# Altium schematic sheet CW551_MainBoard_Ethernet.SchDoc
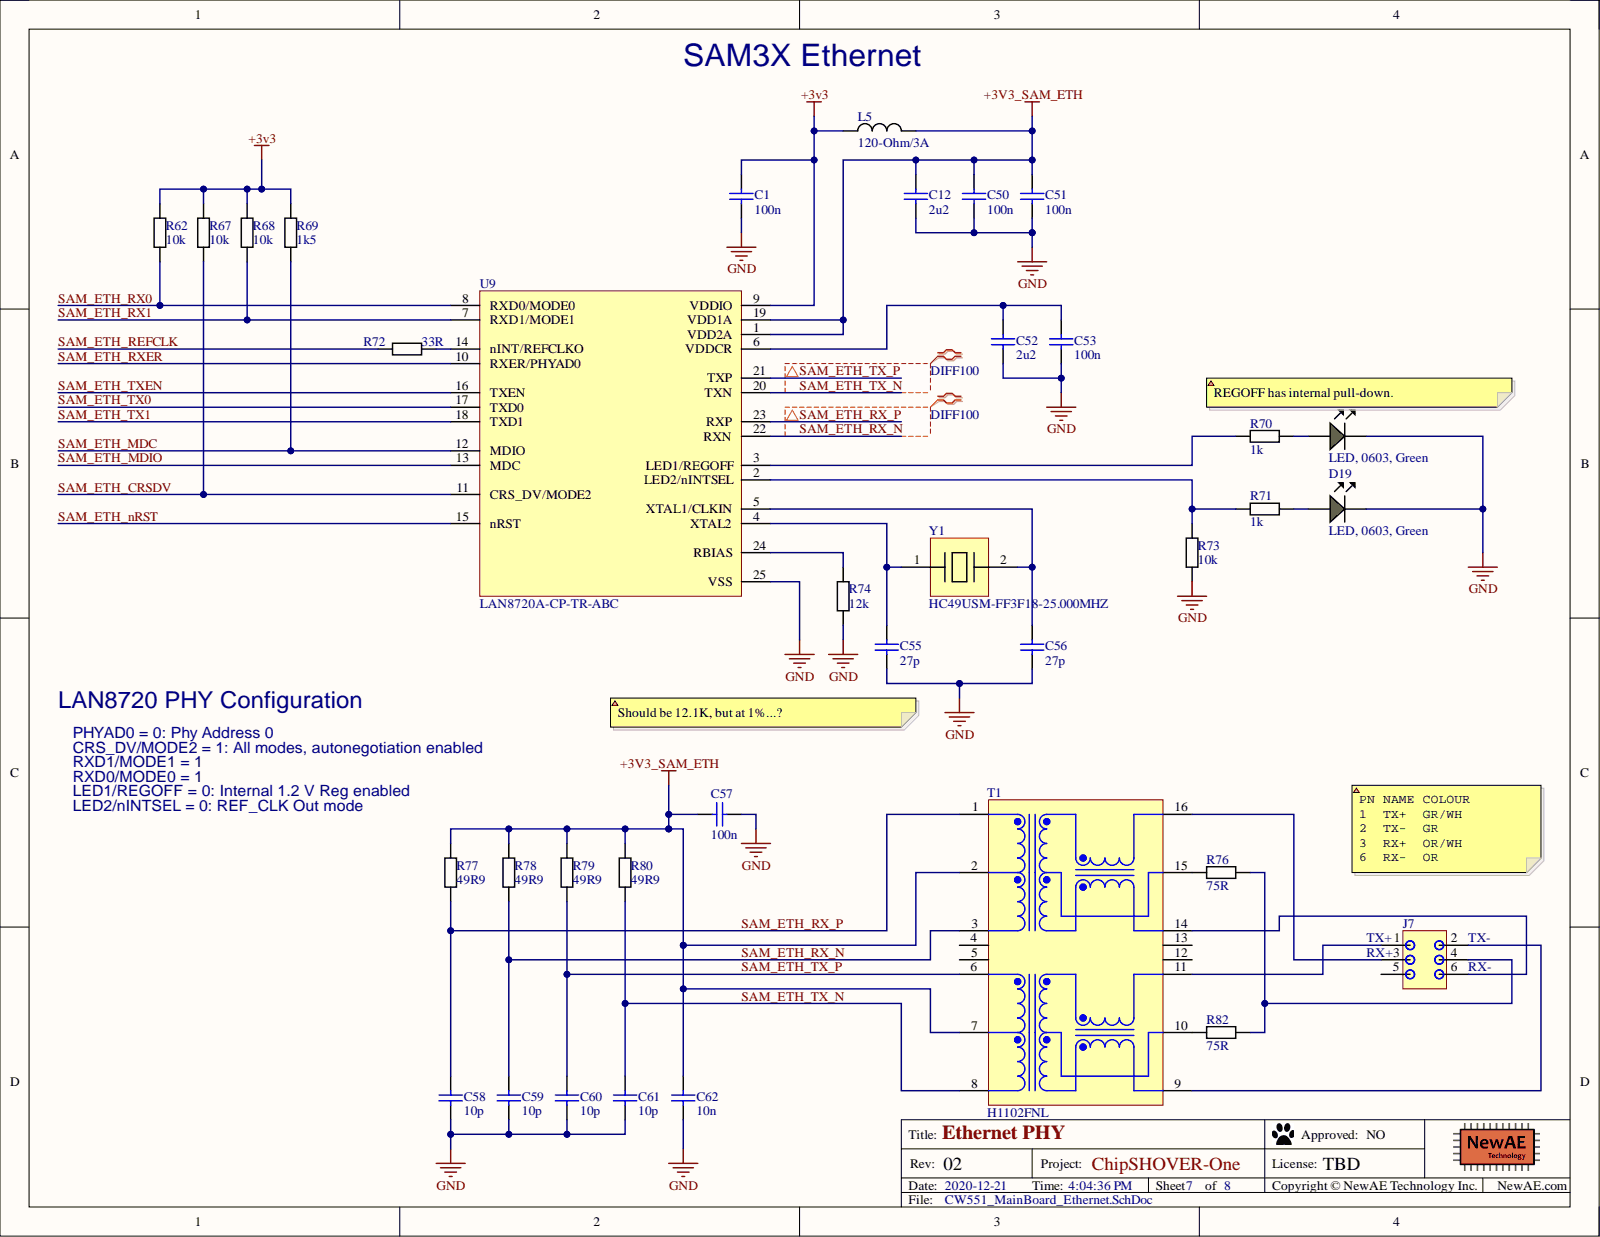
[diagram p0: CW551_MainBoard_Ethernet.SchDoc]

## Parts
C1  100n  [0603]
C12  2u2  [0603]
C50  100n  [0603]
C51  100n  [0603]
C52  2u2  [0603]
C53  100n  [0603]
C55  27p  [0603]
C56  27p  [0603]
C57  100n  [0402]
C58  10p  [0603]
C59  10p  [0603]
C60  10p  [0603]
C61  10p  [0603]
C62  10n  [0603]
D18  LED, 0603, Green  [LED-0603-GREEN]
D19  LED, 0603, Green  [LED-0603-GREEN]
J7  HDR, Male, 6-Pin, 2 Row, Shrouded  [HDR_6PIN_2ROW_SHROUD]  (value not drawn)
L5  120-Ohm/3A  [0603]
R62  10k  [0603]
R67  10k  [0603]
R68  10k  [0603]
R69  1k5  [0603]
R70  1k  [0603]
R71  1k  [0603]
R72  33R  [0603]
R73  10k  [0603]
R74  12k  [0603]
R76  75R  [0603]
R77  49R9  [0805]
R78  49R9  [0805]
R79  49R9  [0805]
R80  49R9  [0805]
R82  75R  [0603]
T1  H1102FNL  [PULS-H1102FNL_V]
U9  LAN8720A-CP-TR-ABC  [QFN50P400X400X90_HS-25N]
Y1  HC49USM-FF3F18-25.000MHZ  [HC49SD]

## Nets
+3v3: C1.1 C12.1 C50.1 C51.1 C57.1 C62.1 L5.1 L5.2 R62.1 R67.1 R68.1 R69.1 R77.1 R78.1 R79.1 R80.1 T1.2 T1.7 U9.1(VDD2A) U9.9(VDDIO) U9.19(VDD1A)
GND: C1.2 C12.2 C50.2 C51.2 C52.2 C53.2 C55.2 C56.2 C57.2 C58.2 C59.2 C60.2 C61.2 C62.2 D18.K D19.K R73.2 R74.2 U9.25(VSS)
SAM_ETH_CRSDV: R67.2 U9.11(CRS_DV/MODE2)
SAM_ETH_MDC: R69.2 U9.12(MDIO)
SAM_ETH_MDIO: U9.13(MDC)
SAM_ETH_nRST: U9.15(nRST)
SAM_ETH_REFCLK: R72.1
SAM_ETH_RX0: R62.2 U9.8(RXD0/MODE0)
SAM_ETH_RX1: R68.2 U9.7(RXD1/MODE1)
SAM_ETH_RX_N: C59.1 R78.2 T1.3 U9.22(RXN)
SAM_ETH_RX_P: C58.1 R77.2 T1.1 U9.23(RXP)
SAM_ETH_RXER: U9.10(RXER/PHYAD0)
SAM_ETH_TX0: U9.17(TXD0)
SAM_ETH_TX1: U9.18(TXD1)
SAM_ETH_TX_N: C61.1 R80.2 T1.8 U9.20(TXN)
SAM_ETH_TX_P: C60.1 R79.2 T1.6 U9.21(TXP)
SAM_ETH_TXEN: U9.16(TXEN)
NetC52_1: C52.1 C53.1 U9.6(VDDCR)
NetC55_1: C55.1 U9.4(XTAL2) Y1.1
NetC56_1: C56.1 U9.5(XTAL1/CLKIN) Y1.2
NetD18_A: D18.A R70.2
NetD19_A: D19.A R71.2
NetJ7_1: J7.1 T1.11
NetJ7_2: J7.2 T1.9
NetJ7_3: J7.3 T1.16
NetJ7_4: J7.4 R76.2 R82.2
NetJ7_6: J7.6 T1.14
NetR70_1: R70.1 U9.3(LED1/REGOFF)
NetR71_1: R71.1 R73.1 U9.2(LED2/nINTSEL)
NetR72_2: R72.2 U9.14(nINT/REFCLKO)
NetR74_1: R74.1 U9.24(RBIAS)
NetR76_1: R76.1 T1.15
NetR82_1: R82.1 T1.10

Not connected: J7 5; T1 4 5 12 13

## Library data of the parts
C1 C50 C51 C53 = CCHIP-100n-0603: 100n, 0603; Manufacture 1: Kemet; Manufacture Part Number 1: C0603C104K5RACTU; Case-Metric: 1608; Component Group: Capacitor; Database Table Name: main; Rated Voltage: 50VDC; Case-EIA: 0603; Component Kind: Standard; Component Type: Standard; LCSC Equivalent: C1591; Library Name: NewAE_AltiumLib.DBLib; Mounting Technology: SMT; Part Number: CCHIP-100n-0603; Pin Count: 2; Supplier 1: Digi-Key; Supplier Part Number 1: 399-5089-2-ND; Value: 100n
C12 C52 = CCHIP-2u2-0603: 2u2, 0603; Manufacture 1: Samsung; Manufacture Part Number 1: CL10B225KP8NNNC; Case-Metric: 1608; Component Group: Capacitor; Database Table Name: main; Rated Voltage: 10VDC; Case-EIA: 0603; Component Kind: Standard; Component Type: Standard; LCSC Equivalent: C57895; Library Name: NewAE_AltiumLib.DBLib; Mounting Technology: SMT; Part Number: CCHIP-2u2-0603; Pin Count: 2; Supplier 1: Digi-Key; Supplier Part Number 1: 1276-1134-2-ND; Value: 2u2
C55 C56 = CCHIP-27P-0603-50V: CAP CER 27PF 50V C0G/NP0 0603; Manufacture 1: Murata Electronics North America; Manufacture Part Number 1: GRM1885C1H270JA01D; Case-Metric: 1608; Component Group: Capacitor; Database Table Name: main; PP Material Stack: 0; Tolerance: ±5%; Case-EIA: 0603; Component Kind: Standard; Component Type: Standard; LCSC Equivalent: C85976; Library Name: NewAE_AltiumLib.DBLib; Mounting Technology: SMT; Part Number: CCHIP-27P-0603-50V; Pin Count: 2; PP Rotation: 0; Rated Voltage: 50V; Supplier 1: Digi-Key; Supplier Part Number 1: 490-1413-1-ND; Value: 27p
C57 = CCHIP-100n-0402: 100n, 0402; Component Group: Capacitor; Database Table Name: main; Case-EIA: 0402; Component Kind: Standard; Component Type: Standard; Library Name: NewAE_AltiumLib.DBLib; Mounting Technology: SMT; Part Number: CCHIP-100n-0402; Pin Count: 2; Value: 100n
C58-C61 = CCHIP-10p-0603: 10p, 0603; Case-Metric: 1608; Component Group: Capacitor; Database Table Name: main; Case-EIA: 0603; Component Kind: Standard; Component Type: Standard; LCSC Equivalent: C313084; Library Name: NewAE_AltiumLib.DBLib; Mounting Technology: SMT; Part Number: CCHIP-10p-0603; Pin Count: 2; Supplier 1: Digi-Key; Supplier Part Number 1: 399-1049-1-ND; Value: 10p
C62 = CCHIP-10n-0603: 10n, 0603; Case-Metric: 1608; Component Group: Capacitor; Database Table Name: main; Case-EIA: 0603; Component Kind: Standard; Component Type: Standard; Library Name: NewAE_AltiumLib.DBLib; Mounting Technology: SMT; Part Number: CCHIP-10n-0603; Pin Count: 2; Supplier 1: Digi-Key; Supplier Part Number 1: 399-1091-1-ND; Value: 10n
D18 D19 = LEDCHIP_0603_GREEN: Chip LED, Green; Manufacture 1: Kingbright; Manufacture Part Number 1: APT1608CGCK; Component Group: Optical; Database Table Name: main; PP Material Stack: 16; PP Rotation: -90; Component Kind: Standard; Component Type: Standard; LCSC Equivalent: C192666; Library Name: NewAE_AltiumLib.DBLib; Mounting Technology: SMT; Part Number: LEDCHIP_0603_GREEN; Pin Count: 2; Supplier 1: Digi-Key; Supplier Part Number 1: 754-1116-1-ND
J7 = HDR_6PIN_2ROW_SHROUD: Manufacture 1: FCI Connectors; Manufacture Part Number 1: 75869-131LF; Component Group: Connector; Database Table Name: main; Component Kind: Standard; Component Type: Standard; Description: HDR, Male, 6-Pin, 2 Row, Shrouded; Library Name: NewAE_AltiumLib.DBLib; Mounting Technology: Through-hole; Part Number: HDR_6PIN_2ROW_SHROUD; Pin Count: 6; RoHS: Yes; Supplier 1: Digi-Key; Supplier Part Number 1: 609-2845-ND
L5 = FBCHIP-120R-0603: 120 Ohm @ 100 MHz, 3A rated FB; Manufacture 1: Murata Electronics; Manufacture Part Number 1: BLM18SG121TN1D; Case-Metric: 1680; Component Group: Inductor; Database Table Name: main; PP Material Stack: 0; Value: 120R/3A; Case-EIA: 0603; Component Kind: Standard; Component Type: Standard; Library Name: NewAE_AltiumLib.DBLib; Part Number: FBCHIP-120R-0603; Pin Count: 2; PP Rotation: 0; Rated Power: 3A; Supplier 1: Digi-Key; Supplier Part Number 1: 490-3996-1-ND
R62 R67 R68 R73 = RCHIP-10K-0603: 10k res, 0603, 1%, 1/10W; Manufacture 1: Yageo; Manufacture Part Number 1: RC0603FR-0710KL; Case-Metric: 1608; Component Group: Resistor; Database Table Name: main; Rated Power: 0.1W; Rated Voltage: 75VDC; Case-EIA: 0603; Component Kind: Standard; Component Type: Standard; Library Name: NewAE_AltiumLib.DBLib; Mounting Technology: SMT; Part Number: RCHIP-10K-0603; Pin Count: 2; Supplier 1: Digi-Key; Supplier Part Number 1: 311-10.0KHRCT-ND; Temperature Range: -55C to 155C; Tolerance: 1%; Value: 10k
R69 = RCHIP-1K5-0603: 1k5 res, 0603, 1%, 1/10W; Manufacture 1: Yageo; Manufacture Part Number 1: RC0603FR-071K5L; Case-Metric: 1608; Component Group: Resistor; Database Table Name: main; Rated Power: 0.1W; Rated Voltage: 75VDC; Case-EIA: 0603; Component Kind: Standard; Component Type: Standard; Library Name: NewAE_AltiumLib.DBLib; Mounting Technology: SMT; Part Number: RCHIP-1K5-0603; Pin Count: 2; Supplier 1: Digi-Key; Supplier Part Number 1: 311-1.50KHRCT-ND; Temperature Range: -55C to 155C; Tolerance: 1%; Value: 1k5
R70 R71 = RCHIP-1K-0603: 1k res, 0603, 1%, 1/10W; Manufacture 1: Yageo; Manufacture Part Number 1: RC0603FR-071KL; Case-Metric: 1608; Component Group: Resistor; Database Table Name: main; Rated Power: 0.1W; Rated Voltage: 75VDC; Case-EIA: 0603; Component Kind: Standard; Component Type: Standard; Library Name: NewAE_AltiumLib.DBLib; Mounting Technology: SMT; Part Number: RCHIP-1K-0603; Pin Count: 2; Supplier 1: Digi-Key; Supplier Part Number 1: 311-1.00KHRCT-ND; Temperature Range: -55C to 155C; Tolerance: 1%; Value: 1k
R72 = RCHIP-33R-0603: 33R res, 0603, 1%, 1/10W; Manufacture 1: Yageo; Manufacture Part Number 1: RC0603FR-0733RL; Case-Metric: 1608; Component Group: Resistor; Database Table Name: main; Rated Power: 0.1W; Rated Voltage: 75VDC; Case-EIA: 0603; Component Kind: Standard; Component Type: Standard; Library Name: NewAE_AltiumLib.DBLib; Mounting Technology: SMT; Part Number: RCHIP-33R-0603; Pin Count: 2; Supplier 1: Digi-Key; Supplier Part Number 1: 311-33.0HRCT-ND; Temperature Range: -55C to 155C; Tolerance: 1%; Value: 33R
R74 = RCHIP-12K-0603: 12k res, 0603, 1%, 1/10W; Manufacture 1: Yageo; Manufacture Part Number 1: RC0603FR-0712KL; Case-Metric: 1608; Component Group: Resistor; Database Table Name: main; Rated Power: 0.1W; Rated Voltage: 75VDC; Case-EIA: 0603; Component Kind: Standard; Component Type: Standard; Library Name: NewAE_AltiumLib.DBLib; Mounting Technology: SMT; Part Number: RCHIP-12K-0603; Pin Count: 2; Supplier 1: Digi-Key; Supplier Part Number 1: 311-12.0KHRCT-ND; Temperature Range: -55C to 155C; Tolerance: 1%; Value: 12k
R76 R82 = RCHIP-75R-0603: 75R res, 0603, 1%, 1/10W; Manufacture 1: Yageo; Manufacture Part Number 1: RC0603FR-0775RL; Case-Metric: 1608; Component Group: Resistor; Database Table Name: main; Rated Power: 0.1W; Rated Voltage: 75VDC; Case-EIA: 0603; Component Kind: Standard; Component Type: Standard; Library Name: NewAE_AltiumLib.DBLib; Mounting Technology: SMT; Part Number: RCHIP-75R-0603; Pin Count: 2; Supplier 1: Digi-Key; Supplier Part Number 1: 311-75.0HRCT-ND; Temperature Range: -55C to 155C; Tolerance: 1%; Value: 75R
R77-R80 = RCHIP-49R9-0805: 49r9 res, 0805, 1%, 1/8W; Manufacture 1: Yageo; Manufacture Part Number 1: RC0805FR-0749R9L; Case-Metric: 2012; Component Group: Resistor; Database Table Name: main; Rated Power: 0.125W; Rated Voltage: 75VDC; Case-EIA: 0805; Component Kind: Standard; Component Type: Standard; Library Name: NewAE_AltiumLib.DBLib; Mounting Technology: SMT; Part Number: RCHIP-49R9-0805; Pin Count: 2; RoHS: Yes; Supplier 1: Digi-Key; Supplier Part Number 1: 311-49.9CRCT-ND; Temperature Range: -55C to 155C; Tolerance: 1%; Value: 49R9
T1 = CMP-2000-06379-1: 100 Base-TX Single Port Magnetics with PoE* Option and 1:1 Turns Ratios, -40 to 85 degC, 16-Pin SMD, RoHS, Tube; Manufacturer: Pulse Electronics; Other Names: 553-2196; Other Related Documents: PackagesFNLvs.NL; Category: Transformers; Component Kind: Standard; Datasheet URL: http://productfinder.pulseeng.com/products/datasheets/H1102FNL.pdf; Datasheet Version: Rev. A, 06/2014; Family: PulseTransformers; LCSC Part: C108351; Library Name: Altium Content Vault; Manufacturer URL: http://www.pulseelectronics.com/; Mounting Technology: SurfaceMount; Package Description: 16-Pin Surface Mount Device, Body 12.7 x 8.79 mm, Pitch 1.27 mm; Package Reference: H1102FNL; Standard Package: 40
U9 = LAN8720A-CP-TR-ABC: IC TXRX ETHERNET 24QFN; Manufacturer: Microchip; Category: Interface ICs; Component Kind: Standard; ComponentLink1Description: http://www.microchip.com/mymicrochip/filehandler.aspx?ddocname=en586588&src-supplier=Digi-Key; ComponentLink1URL: http://www.microchip.com/mymicrochip/filehandler.aspx?ddocname=en586588&src-supplier=Digi-Key; ComponentLink2Description: http://www.microchip.com/mymicrochip/filehandler.aspx?ddocname=en562076&src-supplier=Digi-Key; ComponentLink2URL: http://www.microchip.com/mymicrochip/filehandler.aspx?ddocname=en562076&src-supplier=Digi-Key; LCSC Part: C511283; Library Name: NewAE_AltiumLib.SchLib; Manufacturer Part Number: LAN8720A-CP-TR-ABC; Pricing 1: 1=1.01000, 25=0.84000, 100=0.78000 (USD); RoHS: No; Stock 1: 2886; Supplier 1: Digi-Key; Supplier Part Number 1: LAN8720A-CP-TR-ABCCT-ND
Y1 = HC49USM-FF3F18-25.000MHZ: CRYSTAL 25.0000MHZ 18PF SMD; Manufacturer: ILSI; Component Kind: Standard; Library Name: NewAE_AltiumLib.SchLib; Manufacturer Part Number: HC49USM-FF3F18-25.000MHZ; Pricing 1: 1=0.29000, 10=0.24000, 50=0.21600, 100=0.19200, 500=0.18240 (USD); RoHS: No; Stock 1: 900; Supplier 1: Digi-Key; Supplier Part Number 1: 2780-HC49USM-FF3F18-25.000MHZCT-ND
